# Altium schematic sheet [3]CAN.SchDoc([3]CAN)
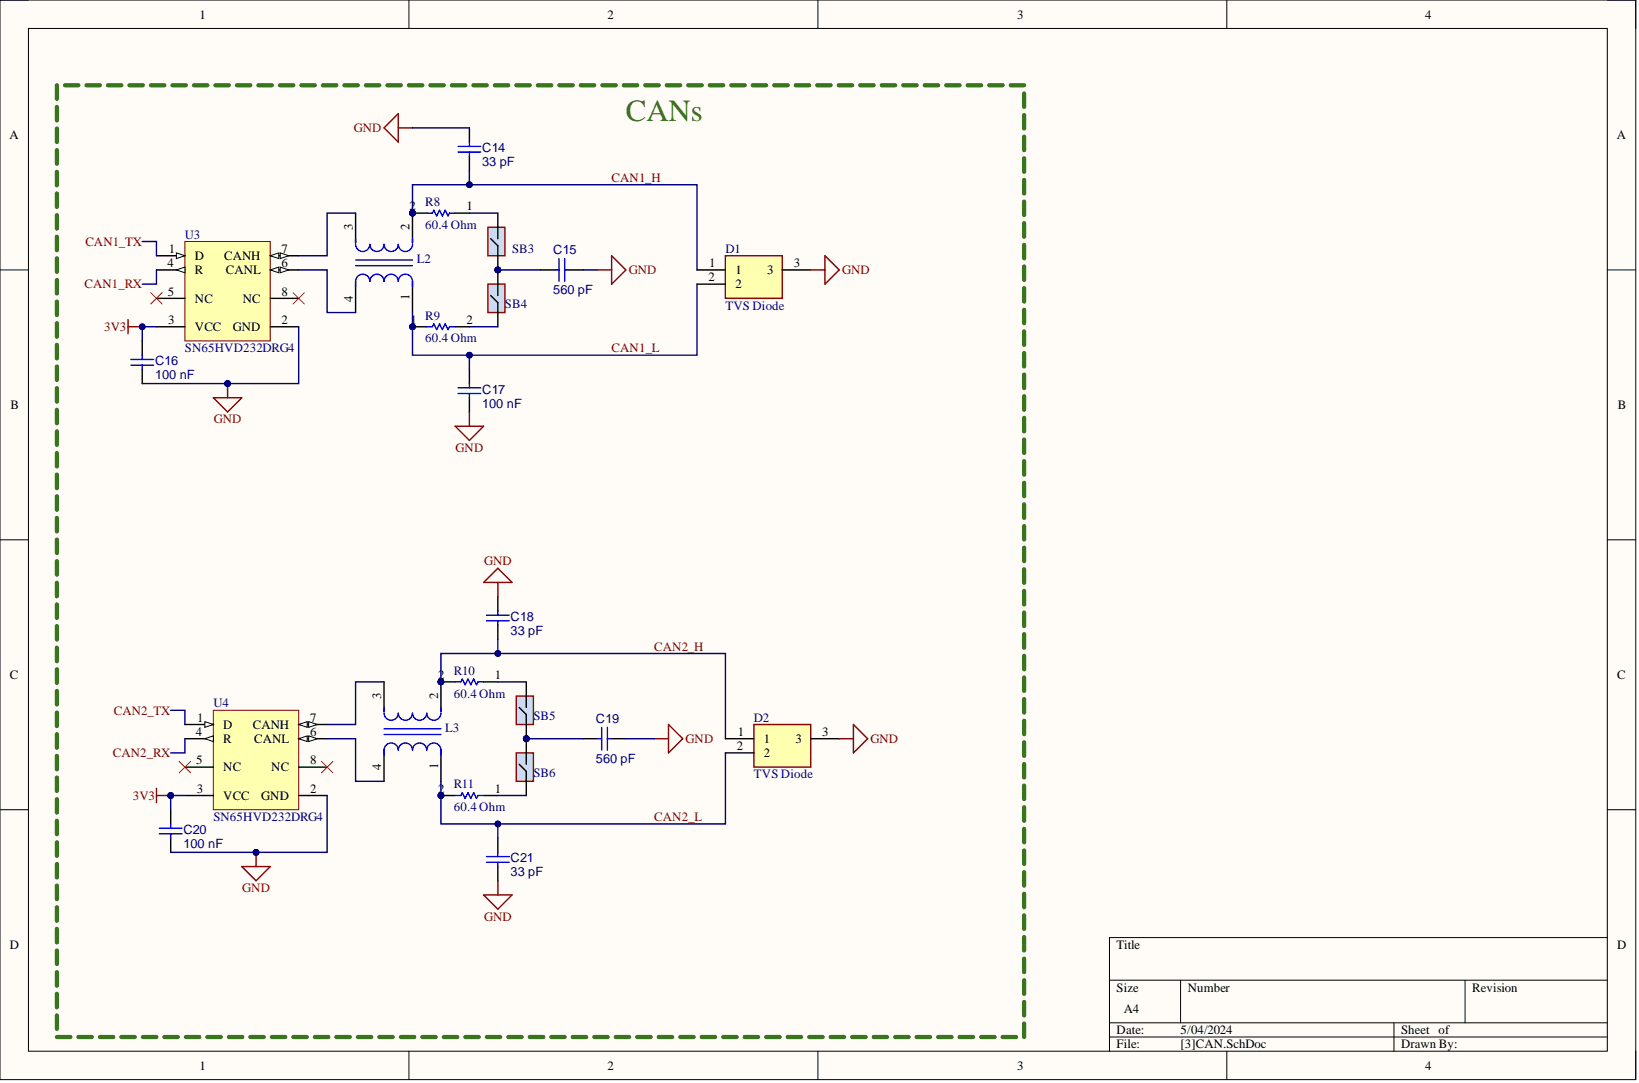
[diagram p0: [3]CAN.SchDoc]

## Parts
C14  33 pF
C15  560 pF
C16  100 nF
C17  100 nF
C18  33 pF
C19  560 pF
C20  100 nF
C21  33 pF
D1  TVS Diode
D2  TVS Diode
L2  ACT45B-101-2P-TL003  (value not drawn)
L3  ACT45B-101-2P-TL003  (value not drawn)
R8  60.4 Ohm
R9  60.4 Ohm
R10  60.4 Ohm
R11  60.4 Ohm
SB3  SolderBridge_0805  (value not drawn)  (no BOM)
SB4  SolderBridge_0805  (value not drawn)  (no BOM)
SB5  SolderBridge_0805  (value not drawn)  (no BOM)
SB6  SolderBridge_0805  (value not drawn)  (no BOM)
U3  SN65HVD232DRG4  [SN65HVD232]
U4  SN65HVD232DRG4  [SN65HVD232]

## Nets
3V3: C16.1 C20.1 U3.3(VCC) U4.3(VCC)
GND: C14.1 C15.1 C16.2 C17.1 C18.1 C19.1 C20.2 C21.1 D1.3 D2.3 U3.2(GND) U4.2(GND)
CAN1_H: C14.2 D1.1 L2.2 R8.2
CAN1_L: C17.2 D1.2 L2.1 R9.1
CAN1_RX: U3.4(R)
CAN1_TX: U3.1(D)
CAN2_H: C18.2 D2.1 L3.2 R10.2
CAN2_L: C21.2 D2.2 L3.1 R11.2
CAN2_RX: U4.4(R)
CAN2_TX: U4.1(D)
NetC15_2: C15.2 SB3.1 SB4.2
NetC19_2: C19.2 SB5.1 SB6.2
NetL2_3: L2.3 U3.7(CANH)
NetL2_4: L2.4 U3.6(CANL)
NetL3_3: L3.3 U4.7(CANH)
NetL3_4: L3.4 U4.6(CANL)
NetR8_1: R8.1 SB3.2
NetR9_2: R9.2 SB4.1
NetR10_1: R10.1 SB5.2
NetR11_1: R11.1 SB6.1

Not connected: U3 5(NC) 8(NC); U4 5(NC) 8(NC)

## Library data of the parts
C14 C18 C21 = CMP-001-00085-5: VJ0805A330JXACW1BC; Tech: SMD; Component Kind: Standard; ComponentLink1Description: Website; Package: 0805; Library Name: EMEA- Squadra Corse PoliTO-  Politecnico di Torino
C15 C19 = CMP-001-00085-5: VJ0805Y561JXXPW1BC; Tech: SMD; Component Kind: Standard; ComponentLink1Description: Website; Package: 0805; Library Name: EMEA- Squadra Corse PoliTO-  Politecnico di Torino
C16 C17 C20 = CMP-001-00085-5: VJ0805Y104MXATW1BC; Tech: SMD; Component Kind: Standard; ComponentLink1Description: Website; Package: 0805; Library Name: EMEA- Squadra Corse PoliTO-  Politecnico di Torino
D1 D2 = CMP-030-000036-3: ESDCAN24-2BLY; Tech: SMD; Component Kind: Standard; Package: SOT-23-3; Library Name: EMEA- Squadra Corse PoliTO-  Politecnico di Torino
L2 L3 = CMP-08256-000007-1: CMC 100UH 150MA 2LN SMD AEC-Q200; Manufacturer: TDK; Automotive Grade: Grade 0; Impedance At Frequency: 5800Ω @ 10MHz; Rms Current: 0.15A; Series Resistance: 2Ω; Test Frequency: 100kHz; Tolerance: +50/-30%; Automotive: Yes; Category: Ind; Component Kind: Standard; ComponentLink2Description: Datasheet; ComponentLink2URL: https://product.tdk.com/info/en/catalog/datasheets/cmf_automotive_signal_act45b_en.pdf; Composition: Wirewound; Device Class L1: Passive Components; Device Class L2: EMI / RFI Components; Device Class L3: Common Mode Chokes; Digikey Description: CMC 100UH 150MA 2LN SMD AEC-Q200; Height: 3mm; Inductance: 100uH; Lead Free: Yes; Library Name: Altium Content Vault; Manufacturer Part Number: ACT45B-101-2P-TL003; Mouser Description: Common Mode Chokes / Filters 5000ohms 100uH 150mA CAN-BUS AEC-Q200; Octopart: https://octopart.com/act45b-101-2p-tl003-tdk-11353565; Package: ACT45B; RoHS: TRUE; Temperature: -40°C to +150°C; Temperature Range High: +150°C; Temperature Range Low: -40°C; Value: 100uH
R8-R11 = CMP-009-00179-5: CRCW060360R4FKEA; Tech: SMD; Component Kind: Standard; ComponentLink1Description: Website; Package: 0603; Library Name: EMEA- Squadra Corse PoliTO-  Politecnico di Torino
SB3-SB6 = CMP-00006-5: 0805 Solder Bridge; Library Name: EMEA- Squadra Corse PoliTO-  Politecnico di Torino
U3 U4 = CMP-0315-00080-1: 3.3 V Can Transceiver, 17 mA, -40 to 85 degC, 8-pin SOIC (D), Green (RoHS & no Sb/Br); Manufacturer: Texas Instruments; Drivers Per Package: 1; ICC(Max)(mA): 17; Rating: Catalog; Supply Voltage(s)(V): 3.3; Transient Pulse Protection(V): -25 to 25; Code_JEDEC: MS-012AA; Component Kind: Standard; ComponentLink1Description: Datasheet; ComponentLink1URL: http://www.ti.com/general/docs/lit/getliterature.tsp?genericPartNumber=SN65HVD232&fileType=pdf; DatasheetVersion: SLOS346K; Eco-Plan: Green (RoHS & no Sb/Br); Isolated: No; Library Name: Altium Content Vault; Mounting Technology: Surface Mount; PackageDescription: 8-Pin Plastic Small Outline Integrated Circuit, 4.9 x 3.9 mm, Pitch 1.27 mm Pitch; PackageReference: D8; PackageVersion: revM, Jun-2011; PartNumber: SN65HVD232DRG4; Receivers Per Package: 1; SubFamily: CAN
